# Altium schematic sheet [2]-FPGA.SchDoc
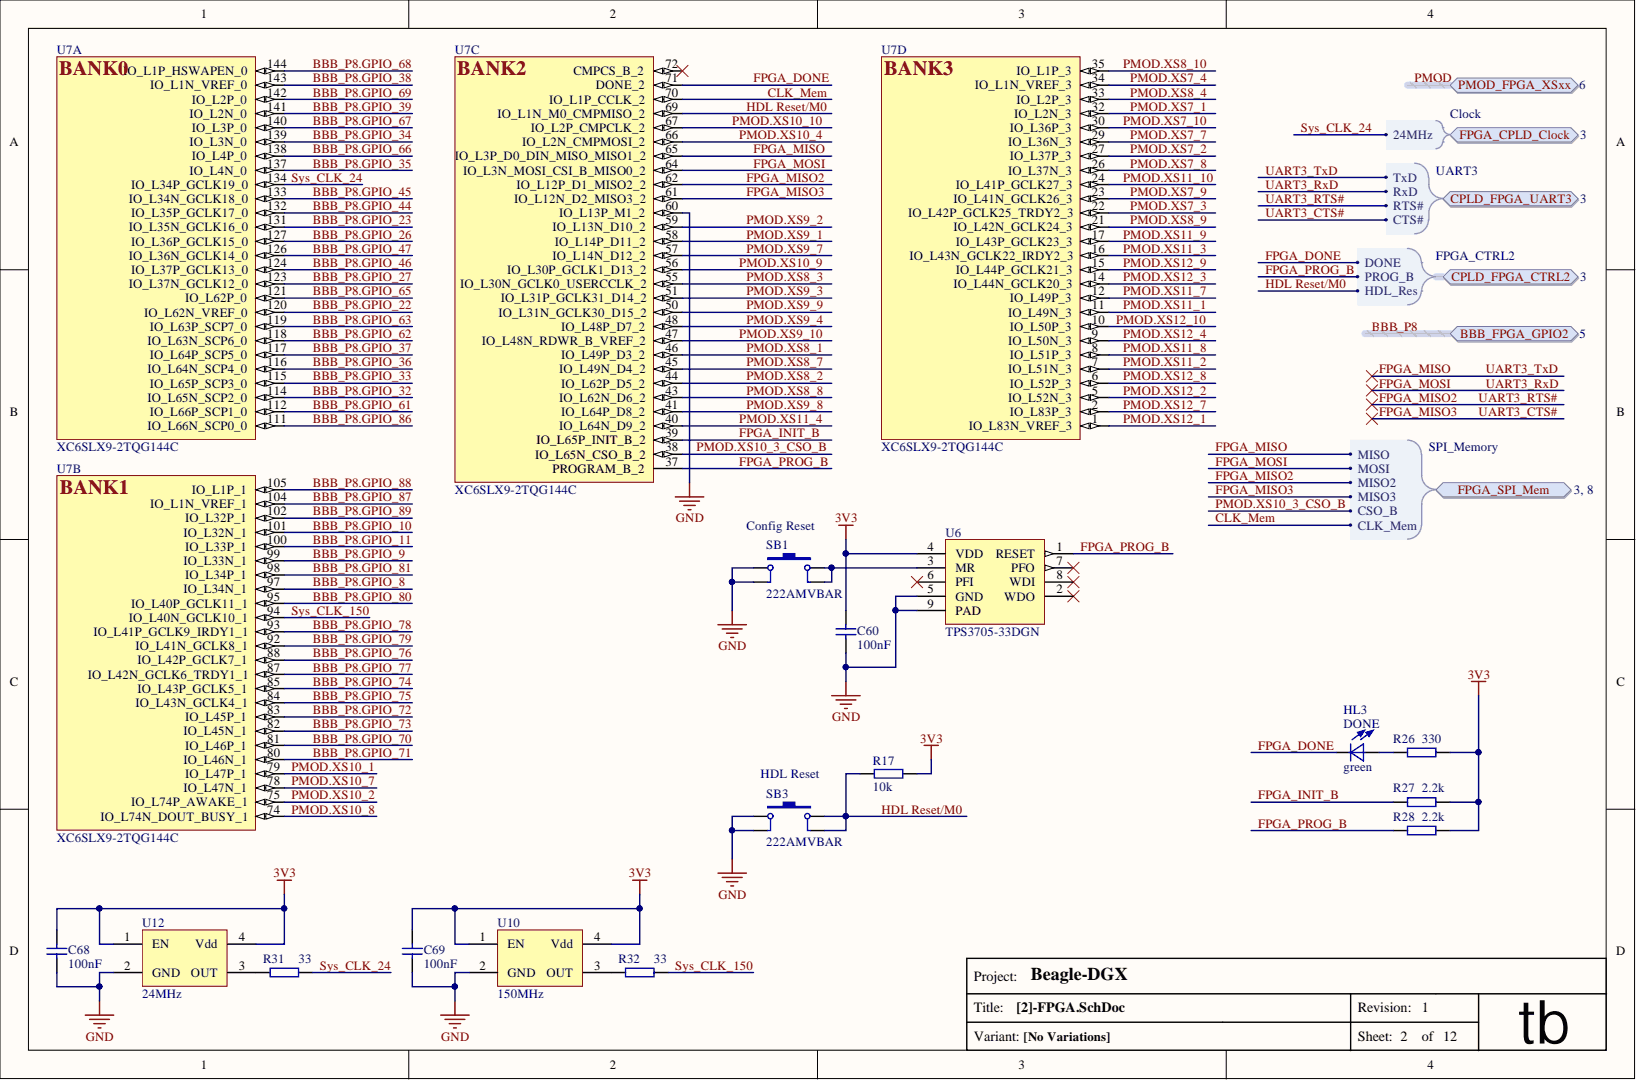
[diagram p0: [2]-FPGA.SchDoc]

## Parts
C60  value 100nF  [C0603]  (hidden comment: CAP)
C68  value 100nF  [C0603]  (hidden comment: CAP)
C69  value 100nF  [C0603]  (hidden comment: CAP)
HL3  DONE  value green  [0805_LED_green]
R17  value 10k  [0603_RESISTOR]  (hidden comment: Resistor)
R26  value 330  [0603_RESISTOR]  (hidden comment: Resistor)
R27  value 2.2k  [0603_RESISTOR]  (hidden comment: Resistor)
R28  value 2.2k  [0603_RESISTOR]  (hidden comment: Resistor)
R31  value 33  [0603_RESISTOR]  (hidden comment: Resistor)
R32  value 33  [0603_RESISTOR]  (hidden comment: Resistor)
SB1  Config Reset  value 222AMVBAR  Manufacturer Part: 222AMVBAR  [Key 6.2x6.2]
SB3  HDL Reset  value 222AMVBAR  Manufacturer Part: 222AMVBAR  [Key 6.2x6.2]
U6  TPS3705-33DGN  [DGNR_pwrpad]
U7  XC6SLX9-2TQG144C  [TQ144_N_Spartan6]  (parts A,B,C,D)
U10  value 150MHz  [OSC_5x7]  (hidden comment: OSC_Crystal Oscillator)
U12  value 24MHz  [OSC_5x7]  (hidden comment: OSC_Crystal Oscillator)

## Ports
BBB_FPGA_GPIO2, BBB_FPGA_GPIO2.GPIO_8, BBB_FPGA_GPIO2.GPIO_9, BBB_FPGA_GPIO2.GPIO_10, BBB_FPGA_GPIO2.GPIO_11, BBB_FPGA_GPIO2.GPIO_22, BBB_FPGA_GPIO2.GPIO_23, BBB_FPGA_GPIO2.GPIO_26, BBB_FPGA_GPIO2.GPIO_27, BBB_FPGA_GPIO2.GPIO_32, BBB_FPGA_GPIO2.GPIO_33, BBB_FPGA_GPIO2.GPIO_34, BBB_FPGA_GPIO2.GPIO_35, BBB_FPGA_GPIO2.GPIO_36, BBB_FPGA_GPIO2.GPIO_37, BBB_FPGA_GPIO2.GPIO_38, BBB_FPGA_GPIO2.GPIO_39, BBB_FPGA_GPIO2.GPIO_44, BBB_FPGA_GPIO2.GPIO_45, BBB_FPGA_GPIO2.GPIO_46, BBB_FPGA_GPIO2.GPIO_47, BBB_FPGA_GPIO2.GPIO_61, BBB_FPGA_GPIO2.GPIO_62, BBB_FPGA_GPIO2.GPIO_63, BBB_FPGA_GPIO2.GPIO_65, BBB_FPGA_GPIO2.GPIO_66, BBB_FPGA_GPIO2.GPIO_67, BBB_FPGA_GPIO2.GPIO_68, BBB_FPGA_GPIO2.GPIO_69, BBB_FPGA_GPIO2.GPIO_70, BBB_FPGA_GPIO2.GPIO_71, BBB_FPGA_GPIO2.GPIO_72, BBB_FPGA_GPIO2.GPIO_73, BBB_FPGA_GPIO2.GPIO_74, BBB_FPGA_GPIO2.GPIO_75, BBB_FPGA_GPIO2.GPIO_76, BBB_FPGA_GPIO2.GPIO_77, BBB_FPGA_GPIO2.GPIO_78, BBB_FPGA_GPIO2.GPIO_79, BBB_FPGA_GPIO2.GPIO_80, BBB_FPGA_GPIO2.GPIO_81, BBB_FPGA_GPIO2.GPIO_86, BBB_FPGA_GPIO2.GPIO_87, BBB_FPGA_GPIO2.GPIO_88, BBB_FPGA_GPIO2.GPIO_89, CPLD_FPGA_CTRL2, CPLD_FPGA_CTRL2.DONE, CPLD_FPGA_CTRL2.HDL_Res, CPLD_FPGA_CTRL2.PROG_B, CPLD_FPGA_UART3, CPLD_FPGA_UART3.CTS#, CPLD_FPGA_UART3.RTS#, CPLD_FPGA_UART3.RxD, CPLD_FPGA_UART3.TxD, FPGA_CPLD_Clock, FPGA_CPLD_Clock.24MHz, FPGA_SPI_Mem, FPGA_SPI_Mem.CLK_Mem, FPGA_SPI_Mem.CSO_B, FPGA_SPI_Mem.MISO, FPGA_SPI_Mem.MISO2, FPGA_SPI_Mem.MISO3, FPGA_SPI_Mem.MOSI, PMOD_FPGA_XSxx, PMOD_FPGA_XSxx.XS7_1, PMOD_FPGA_XSxx.XS7_2, PMOD_FPGA_XSxx.XS7_3, PMOD_FPGA_XSxx.XS7_4, PMOD_FPGA_XSxx.XS7_7, PMOD_FPGA_XSxx.XS7_8, PMOD_FPGA_XSxx.XS7_9, PMOD_FPGA_XSxx.XS7_10, PMOD_FPGA_XSxx.XS8_1, PMOD_FPGA_XSxx.XS8_2, PMOD_FPGA_XSxx.XS8_3, PMOD_FPGA_XSxx.XS8_4, PMOD_FPGA_XSxx.XS8_7, PMOD_FPGA_XSxx.XS8_8, PMOD_FPGA_XSxx.XS8_9, PMOD_FPGA_XSxx.XS8_10, PMOD_FPGA_XSxx.XS9_1, PMOD_FPGA_XSxx.XS9_2, PMOD_FPGA_XSxx.XS9_3, PMOD_FPGA_XSxx.XS9_4, PMOD_FPGA_XSxx.XS9_7, PMOD_FPGA_XSxx.XS9_8, PMOD_FPGA_XSxx.XS9_9, PMOD_FPGA_XSxx.XS9_10, PMOD_FPGA_XSxx.XS10_1, PMOD_FPGA_XSxx.XS10_2, PMOD_FPGA_XSxx.XS10_3_CSO_B, PMOD_FPGA_XSxx.XS10_4, PMOD_FPGA_XSxx.XS10_7, PMOD_FPGA_XSxx.XS10_8, PMOD_FPGA_XSxx.XS10_9, PMOD_FPGA_XSxx.XS10_10, PMOD_FPGA_XSxx.XS11_1, PMOD_FPGA_XSxx.XS11_2, PMOD_FPGA_XSxx.XS11_3, PMOD_FPGA_XSxx.XS11_4, PMOD_FPGA_XSxx.XS11_7, PMOD_FPGA_XSxx.XS11_8, PMOD_FPGA_XSxx.XS11_9, PMOD_FPGA_XSxx.XS11_10, PMOD_FPGA_XSxx.XS12_1, PMOD_FPGA_XSxx.XS12_2, PMOD_FPGA_XSxx.XS12_3, PMOD_FPGA_XSxx.XS12_4, PMOD_FPGA_XSxx.XS12_7, PMOD_FPGA_XSxx.XS12_8, PMOD_FPGA_XSxx.XS12_9, PMOD_FPGA_XSxx.XS12_10

## Nets
3V3: C60.2 C68.2 C69.2 R17.1 R26.2 R27.1 R28.1 U6.4(VDD) U10.1(EN) U10.4(Vdd) U12.1(EN) U12.4(Vdd)
GND: C60.1 C68.1 C69.1 SB1.1 SB1.3 SB3.1 SB3.3 U6.5(GND) U6.9(PAD) U7.60(IO_L13P_M1_2) U10.2(GND) U12.2(GND)
BBB_P8.GPIO_8: U7.97(IO_L34N_1) ; port BBB_FPGA_GPIO2
BBB_P8.GPIO_9: U7.99(IO_L33N_1) ; port BBB_FPGA_GPIO2
BBB_P8.GPIO_10: U7.101(IO_L32N_1) ; port BBB_FPGA_GPIO2
BBB_P8.GPIO_11: U7.100(IO_L33P_1) ; port BBB_FPGA_GPIO2
BBB_P8.GPIO_22: U7.120(IO_L62N_VREF_0) ; port BBB_FPGA_GPIO2
BBB_P8.GPIO_23: U7.131(IO_L35N_GCLK16_0) ; port BBB_FPGA_GPIO2
BBB_P8.GPIO_26: U7.127(IO_L36P_GCLK15_0) ; port BBB_FPGA_GPIO2
BBB_P8.GPIO_27: U7.123(IO_L37N_GCLK12_0) ; port BBB_FPGA_GPIO2
BBB_P8.GPIO_32: U7.114(IO_L65N_SCP2_0) ; port BBB_FPGA_GPIO2
BBB_P8.GPIO_33: U7.115(IO_L65P_SCP3_0) ; port BBB_FPGA_GPIO2
BBB_P8.GPIO_34: U7.139(IO_L3N_0) ; port BBB_FPGA_GPIO2
BBB_P8.GPIO_35: U7.137(IO_L4N_0) ; port BBB_FPGA_GPIO2
BBB_P8.GPIO_36: U7.116(IO_L64N_SCP4_0) ; port BBB_FPGA_GPIO2
BBB_P8.GPIO_37: U7.117(IO_L64P_SCP5_0) ; port BBB_FPGA_GPIO2
BBB_P8.GPIO_38: U7.143(IO_L1N_VREF_0) ; port BBB_FPGA_GPIO2
BBB_P8.GPIO_39: U7.141(IO_L2N_0) ; port BBB_FPGA_GPIO2
BBB_P8.GPIO_44: U7.132(IO_L35P_GCLK17_0) ; port BBB_FPGA_GPIO2
BBB_P8.GPIO_45: U7.133(IO_L34N_GCLK18_0) ; port BBB_FPGA_GPIO2
BBB_P8.GPIO_46: U7.124(IO_L37P_GCLK13_0) ; port BBB_FPGA_GPIO2
BBB_P8.GPIO_47: U7.126(IO_L36N_GCLK14_0) ; port BBB_FPGA_GPIO2
BBB_P8.GPIO_61: U7.112(IO_L66P_SCP1_0) ; port BBB_FPGA_GPIO2
BBB_P8.GPIO_62: U7.118(IO_L63N_SCP6_0) ; port BBB_FPGA_GPIO2
BBB_P8.GPIO_63: U7.119(IO_L63P_SCP7_0) ; port BBB_FPGA_GPIO2
BBB_P8.GPIO_65: U7.121(IO_L62P_0) ; port BBB_FPGA_GPIO2
BBB_P8.GPIO_66: U7.138(IO_L4P_0) ; port BBB_FPGA_GPIO2
BBB_P8.GPIO_67: U7.140(IO_L3P_0) ; port BBB_FPGA_GPIO2
BBB_P8.GPIO_68: U7.144(IO_L1P_HSWAPEN_0) ; port BBB_FPGA_GPIO2
BBB_P8.GPIO_69: U7.142(IO_L2P_0) ; port BBB_FPGA_GPIO2
BBB_P8.GPIO_70: U7.81(IO_L46P_1) ; port BBB_FPGA_GPIO2
BBB_P8.GPIO_71: U7.80(IO_L46N_1) ; port BBB_FPGA_GPIO2
BBB_P8.GPIO_72: U7.83(IO_L45P_1) ; port BBB_FPGA_GPIO2
BBB_P8.GPIO_73: U7.82(IO_L45N_1) ; port BBB_FPGA_GPIO2
BBB_P8.GPIO_74: U7.85(IO_L43P_GCLK5_1) ; port BBB_FPGA_GPIO2
BBB_P8.GPIO_75: U7.84(IO_L43N_GCLK4_1) ; port BBB_FPGA_GPIO2
BBB_P8.GPIO_76: U7.88(IO_L42P_GCLK7_1) ; port BBB_FPGA_GPIO2
BBB_P8.GPIO_77: U7.87(IO_L42N_GCLK6_TRDY1_1) ; port BBB_FPGA_GPIO2
BBB_P8.GPIO_78: U7.93(IO_L41P_GCLK9_IRDY1_1) ; port BBB_FPGA_GPIO2
BBB_P8.GPIO_79: U7.92(IO_L41N_GCLK8_1) ; port BBB_FPGA_GPIO2
BBB_P8.GPIO_80: U7.95(IO_L40P_GCLK11_1) ; port BBB_FPGA_GPIO2
BBB_P8.GPIO_81: U7.98(IO_L34P_1) ; port BBB_FPGA_GPIO2
BBB_P8.GPIO_86: U7.111(IO_L66N_SCP0_0) ; port BBB_FPGA_GPIO2
BBB_P8.GPIO_87: U7.104(IO_L1N_VREF_1) ; port BBB_FPGA_GPIO2
BBB_P8.GPIO_88: U7.105(IO_L1P_1) ; port BBB_FPGA_GPIO2
BBB_P8.GPIO_89: U7.102(IO_L32P_1) ; port BBB_FPGA_GPIO2
CLK_Mem: U7.70(IO_L1P_CCLK_2) ; port FPGA_SPI_Mem
FPGA_DONE: HL3.2 U7.71(DONE_2) ; port CPLD_FPGA_CTRL2
FPGA_INIT_B: R27.2 U7.39(IO_L65P_INIT_B_2)
FPGA_MISO: U7.65(IO_L3P_D0_DIN_MISO_MISO1_2) ; port CPLD_FPGA_UART3, port FPGA_SPI_Mem
FPGA_MISO2: U7.62(IO_L12P_D1_MISO2_2) ; port CPLD_FPGA_UART3, port FPGA_SPI_Mem
FPGA_MISO3: U7.61(IO_L12N_D2_MISO3_2) ; port CPLD_FPGA_UART3, port FPGA_SPI_Mem
FPGA_MOSI: U7.64(IO_L3N_MOSI_CSI_B_MISO0_2) ; port CPLD_FPGA_UART3, port FPGA_SPI_Mem
FPGA_PROG_B: R28.2 U6.1(RESET) U7.37(PROGRAM_B_2) ; port CPLD_FPGA_CTRL2
HDL Reset/M0: R17.2 SB3.2 SB3.4 U7.69(IO_L1N_M0_CMPMISO_2) ; port CPLD_FPGA_CTRL2
PMOD.XS7_1: U7.32(IO_L2N_3) ; port PMOD_FPGA_XSxx
PMOD.XS7_2: U7.27(IO_L37P_3) ; port PMOD_FPGA_XSxx
PMOD.XS7_3: U7.22(IO_L42P_GCLK25_TRDY2_3) ; port PMOD_FPGA_XSxx
PMOD.XS7_4: U7.34(IO_L1N_VREF_3) ; port PMOD_FPGA_XSxx
PMOD.XS7_7: U7.29(IO_L36N_3) ; port PMOD_FPGA_XSxx
PMOD.XS7_8: U7.26(IO_L37N_3) ; port PMOD_FPGA_XSxx
PMOD.XS7_9: U7.23(IO_L41N_GCLK26_3) ; port PMOD_FPGA_XSxx
PMOD.XS7_10: U7.30(IO_L36P_3) ; port PMOD_FPGA_XSxx
PMOD.XS8_1: U7.46(IO_L49P_D3_2) ; port PMOD_FPGA_XSxx
PMOD.XS8_2: U7.44(IO_L62P_D5_2) ; port PMOD_FPGA_XSxx
PMOD.XS8_3: U7.55(IO_L30N_GCLK0_USERCCLK_2) ; port PMOD_FPGA_XSxx
PMOD.XS8_4: U7.33(IO_L2P_3) ; port PMOD_FPGA_XSxx
PMOD.XS8_7: U7.45(IO_L49N_D4_2) ; port PMOD_FPGA_XSxx
PMOD.XS8_8: U7.43(IO_L62N_D6_2) ; port PMOD_FPGA_XSxx
PMOD.XS8_9: U7.21(IO_L42N_GCLK24_3) ; port PMOD_FPGA_XSxx
PMOD.XS8_10: U7.35(IO_L1P_3) ; port PMOD_FPGA_XSxx
PMOD.XS9_1: U7.58(IO_L14P_D11_2) ; port PMOD_FPGA_XSxx
PMOD.XS9_2: U7.59(IO_L13N_D10_2) ; port PMOD_FPGA_XSxx
PMOD.XS9_3: U7.51(IO_L31P_GCLK31_D14_2) ; port PMOD_FPGA_XSxx
PMOD.XS9_4: U7.48(IO_L48P_D7_2) ; port PMOD_FPGA_XSxx
PMOD.XS9_7: U7.57(IO_L14N_D12_2) ; port PMOD_FPGA_XSxx
PMOD.XS9_8: U7.41(IO_L64P_D8_2) ; port PMOD_FPGA_XSxx
PMOD.XS9_9: U7.50(IO_L31N_GCLK30_D15_2) ; port PMOD_FPGA_XSxx
PMOD.XS9_10: U7.47(IO_L48N_RDWR_B_VREF_2) ; port PMOD_FPGA_XSxx
PMOD.XS10_1: U7.79(IO_L47P_1) ; port PMOD_FPGA_XSxx
PMOD.XS10_2: U7.75(IO_L74P_AWAKE_1) ; port PMOD_FPGA_XSxx
PMOD.XS10_3_CSO_B: U7.38(IO_L65N_CSO_B_2) ; port FPGA_SPI_Mem, port PMOD_FPGA_XSxx
PMOD.XS10_4: U7.66(IO_L2N_CMPMOSI_2) ; port PMOD_FPGA_XSxx
PMOD.XS10_7: U7.78(IO_L47N_1) ; port PMOD_FPGA_XSxx
PMOD.XS10_8: U7.74(IO_L74N_DOUT_BUSY_1) ; port PMOD_FPGA_XSxx
PMOD.XS10_9: U7.56(IO_L30P_GCLK1_D13_2) ; port PMOD_FPGA_XSxx
PMOD.XS10_10: U7.67(IO_L2P_CMPCLK_2) ; port PMOD_FPGA_XSxx
PMOD.XS11_1: U7.11(IO_L49N_3) ; port PMOD_FPGA_XSxx
PMOD.XS11_2: U7.7(IO_L51N_3) ; port PMOD_FPGA_XSxx
PMOD.XS11_3: U7.16(IO_L43N_GCLK22_IRDY2_3) ; port PMOD_FPGA_XSxx
PMOD.XS11_4: U7.40(IO_L64N_D9_2) ; port PMOD_FPGA_XSxx
PMOD.XS11_7: U7.12(IO_L49P_3) ; port PMOD_FPGA_XSxx
PMOD.XS11_8: U7.8(IO_L51P_3) ; port PMOD_FPGA_XSxx
PMOD.XS11_9: U7.17(IO_L43P_GCLK23_3) ; port PMOD_FPGA_XSxx
PMOD.XS11_10: U7.24(IO_L41P_GCLK27_3) ; port PMOD_FPGA_XSxx
PMOD.XS12_1: U7.1(IO_L83N_VREF_3) ; port PMOD_FPGA_XSxx
PMOD.XS12_2: U7.5(IO_L52N_3) ; port PMOD_FPGA_XSxx
PMOD.XS12_3: U7.14(IO_L44N_GCLK20_3) ; port PMOD_FPGA_XSxx
PMOD.XS12_4: U7.9(IO_L50N_3) ; port PMOD_FPGA_XSxx
PMOD.XS12_7: U7.2(IO_L83P_3) ; port PMOD_FPGA_XSxx
PMOD.XS12_8: U7.6(IO_L52P_3) ; port PMOD_FPGA_XSxx
PMOD.XS12_9: U7.15(IO_L44P_GCLK21_3) ; port PMOD_FPGA_XSxx
PMOD.XS12_10: U7.10(IO_L50P_3) ; port PMOD_FPGA_XSxx
Sys_CLK_24: R31.2 U7.134(IO_L34P_GCLK19_0) ; port FPGA_CPLD_Clock
Sys_CLK_150: R32.2 U7.94(IO_L40N_GCLK10_1)
NetHL3_1: HL3.1 R26.1
NetR31_1: R31.1 U12.3(OUT)
NetR32_1: R32.1 U10.3(OUT)
NetSB1_2: SB1.2 SB1.4 U6.3(MR)

Not connected: U6 2(WDO) 6(PFI) 7(PFO) 8(WDI); U7 72(CMPCS_B_2)

## Library data of the parts
C60 C68 C69 = CAP: Manufacturer: Vishay / Vitramon; Manufacturer Part: VJ0603Y104JXJPW1BC; Type of Component: Ceramic Capacitor; Component Kind: Standard; Manufacturer Link: http://www.vishay.com/product?docid=28548; Package / Case: 0603; Supplier: mouser; Supplier Link: http://www.mouser.com/ProductDetail/Vishay/VJ0603Y104JXJPW1BC/?qs=%2fha2pyFaduidGoO0X7aK7Xgs%2fjxfhnLiK6SRw4Lz0%252bZK3PJ8DddTrQ%3d%3d; Supplier Part: 77-VJ0603Y104JXJPBC; Library Name: RPI-VITA.IntLib
HL3 = LED: Component Kind: Standard; Library Name: RPI-VITA.IntLib
R17 = Resistor: Manufacturer: Vishay / Dale; Manufacturer Part: CRCW060310K0FKEA; Component Kind: Standard; Manufacturer Link: http://www.vishay.com/product?docid=20035; Package / Case: 0603; Supplier: mouser; Supplier Link: http://www.mouser.com/ProductDetail/Vishay-Dale/CRCW060310K0FKEA/?qs=sGAEpiMZZMu61qfTUdNhGyptJL5EcUtREGTsEKG7Lak%3d; Supplier Part: 71-CRCW0603-10K-E3; Type of Component: Resistor; Library Name: RPI-VITA.IntLib
R27 R28 = Resistor: Manufacturer: Vishay / Dale; Manufacturer Part: CRCW06032K20JNEA; Component Kind: Standard; Manufacturer Link: http://www.vishay.com/product?docid=20035; Package / Case: 0603; Supplier: mouser; Supplier Link: http://www.mouser.com/ProductDetail/Vishay-Dale/CRCW06032K20JNEA/?qs=sGAEpiMZZMvdGkrng054txEw7b1YnvGuRJLBQI6feSk%3d; Supplier Part: 71-CRCW0603J-2.2K-E3; Type of Component: Resistor; Library Name: RPI-VITA.IntLib
R31 R32 = Resistor: Manufacturer: Vishay / Dale; Manufacturer Part: CRCW060333R0FKEA; Component Kind: Standard; Manufacturer Link: http://www.vishay.com/product?docid=20035; Package / Case: 0603; Supplier: mouser; Supplier Link: http://www2.mouser.com/ProductDetail/Vishay-Dale/CRCW060333R0FKEA/?qs=sGAEpiMZZMvdGkrng054t1X0qgDPqELUwAjRIFbU4%252bo%3d; Supplier Part: 71-CRCW0603-33-E3; Type of Component: Resistor; Library Name: RPI-VITA.IntLib
SB1 SB3 = SB_Tactile: Tactile Switches; Manufacturer: E-Switch; Type of Component: Tact Switch; Component Kind: Standard; Package / Case: 6.2 x 6.2 x 5; Supplier: mouser; Supplier Link: http://www.mouser.ee/ProductDetail/CTS-Electronic-Components/222AMVBAR/?qs=sGAEpiMZZMsgGjVA3toVBB5GWLGpesfgV6sVMBFzGDw%3d; Supplier Part: 774-222AMVBAR; Library Name: RPI-VITA.IntLib
U6 = TPS3705DGNR: Processor supervisory circuits with power-fail; Manufacturer: TI; Component Kind: Standard; Manufacturer Link: http://www.ti.com/product/TPS3705-33?CMP=conv-poasamples#samplebuy; Manufacturer Part: TPS3705-33DGN; Package / Case: MSOP-8; Supplier: mouser; Supplier Link: http://www.mouser.ee/search/ProductDetail.aspx?Texas-Instruments%2fTPS3705-33DGN%2f&qs=6gY4t2uohMxyZA1EcEsrJg%3d%3d; Supplier Part: 595-TPS3705-33DGN; Type of Component: Supervisor; Value: TPS3705-33DGN; Library Name: RPI-VITA.IntLib
U7 = XC6SLX9-2TQG144C: Manufacturer: Xilinx; Type of Component: FPGA; Component Kind: Standard; Manufacturer Part: XC6SLX9-2TQG144C; Package / Case: TQG-144; Supplier 1: Hk-Ada; Supplier 1 Part: XC6SLX9-2TQG144C; Value: XC6SLX9-2TQG144C; Library Name: RPI-VITA.IntLib
U10 = OSC_Crystal Oscillator: Manufacturer: Yanshan; Type of Component: Clock Oscillator; Component Kind: Standard; Package / Case: 5 mm x 7 mm; Supplier: aliexpress.com; Supplier Link: http://fr.aliexpress.com/item/Free-shipping-in-stock-30PCS-150-000MHZ-150-000M-150MHZ-5070-7050-5-7-SMD-4Pin/32374230716.html; Supplier Part: 150MHz 4 pin; Library Name: RPI-VITA.IntLib
U12 = OSC_Crystal Oscillator: Manufacturer: KKQ; Type of Component: Clock Oscillator; Component Kind: Standard; Package / Case: 5 mm x 7 mm; Supplier: aliexpress.com; Supplier Link: http://fr.aliexpress.com/item/Free-shipping-24-000MHZ-24-000M-24-000-20Pcs-Lot-5070-7050-5-7-SMD-4Pin/1254133788.html; Supplier Part: 24MHz 4 pin; Library Name: RPI-VITA.IntLib
R26 = Resistor: Component Kind: Standard; Library Name: RPI-VITA.IntLib
